# Altium schematic sheet ESP32_C3FH4.SchDoc(ESP32_C3FH4)
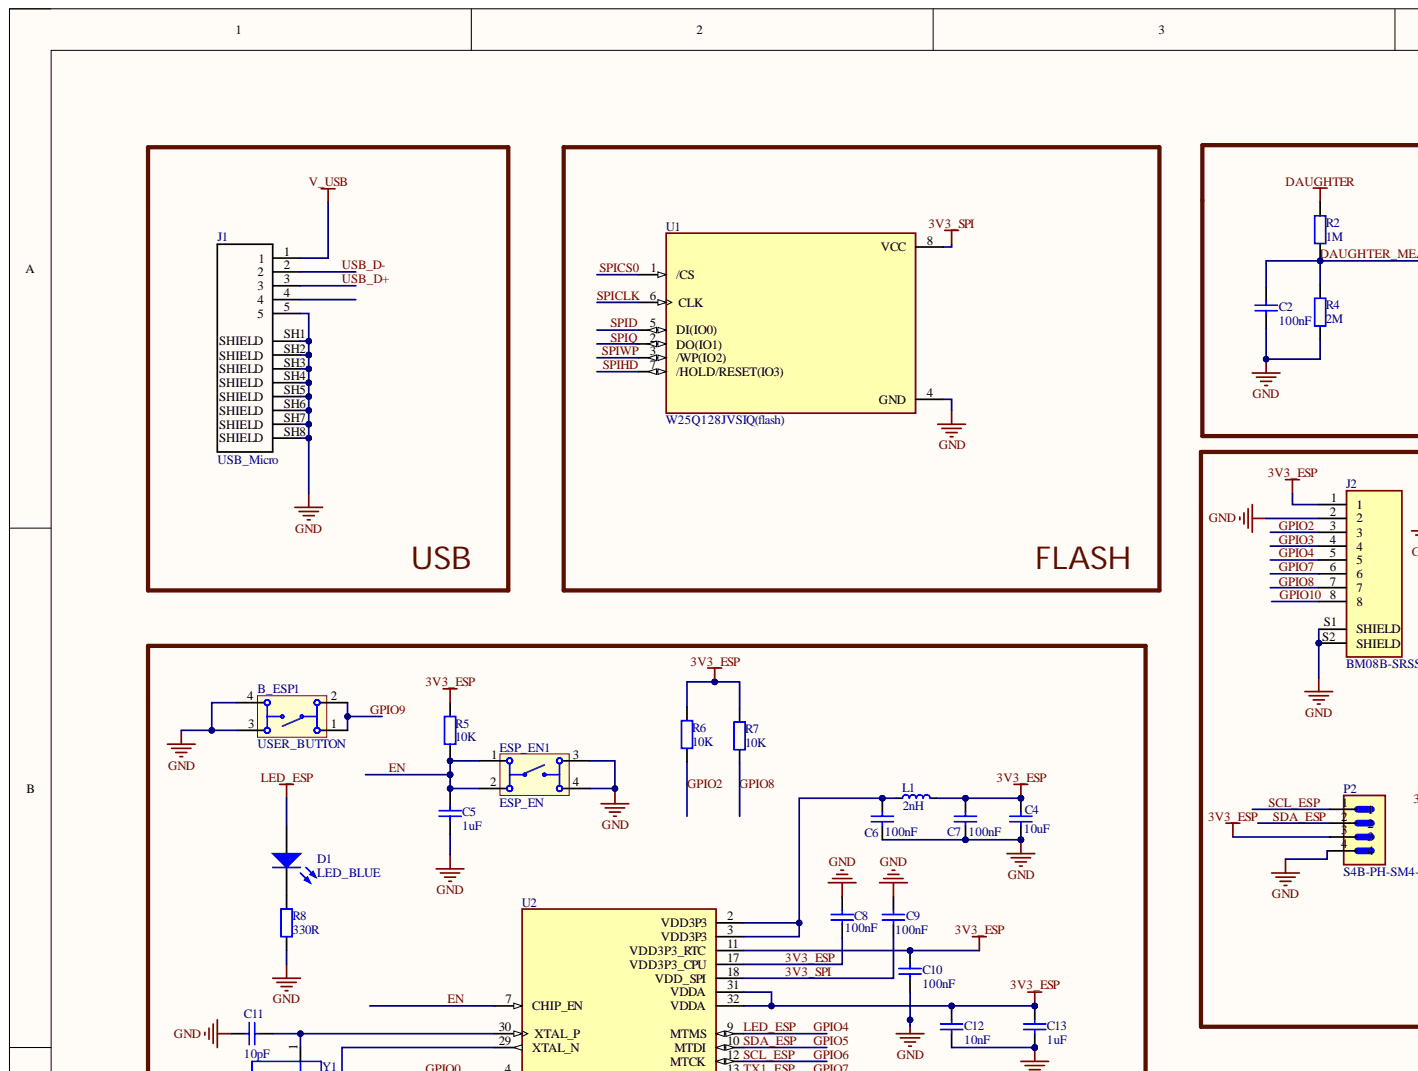
[diagram p0: ESP32_C3FH4.SchDoc, top left]
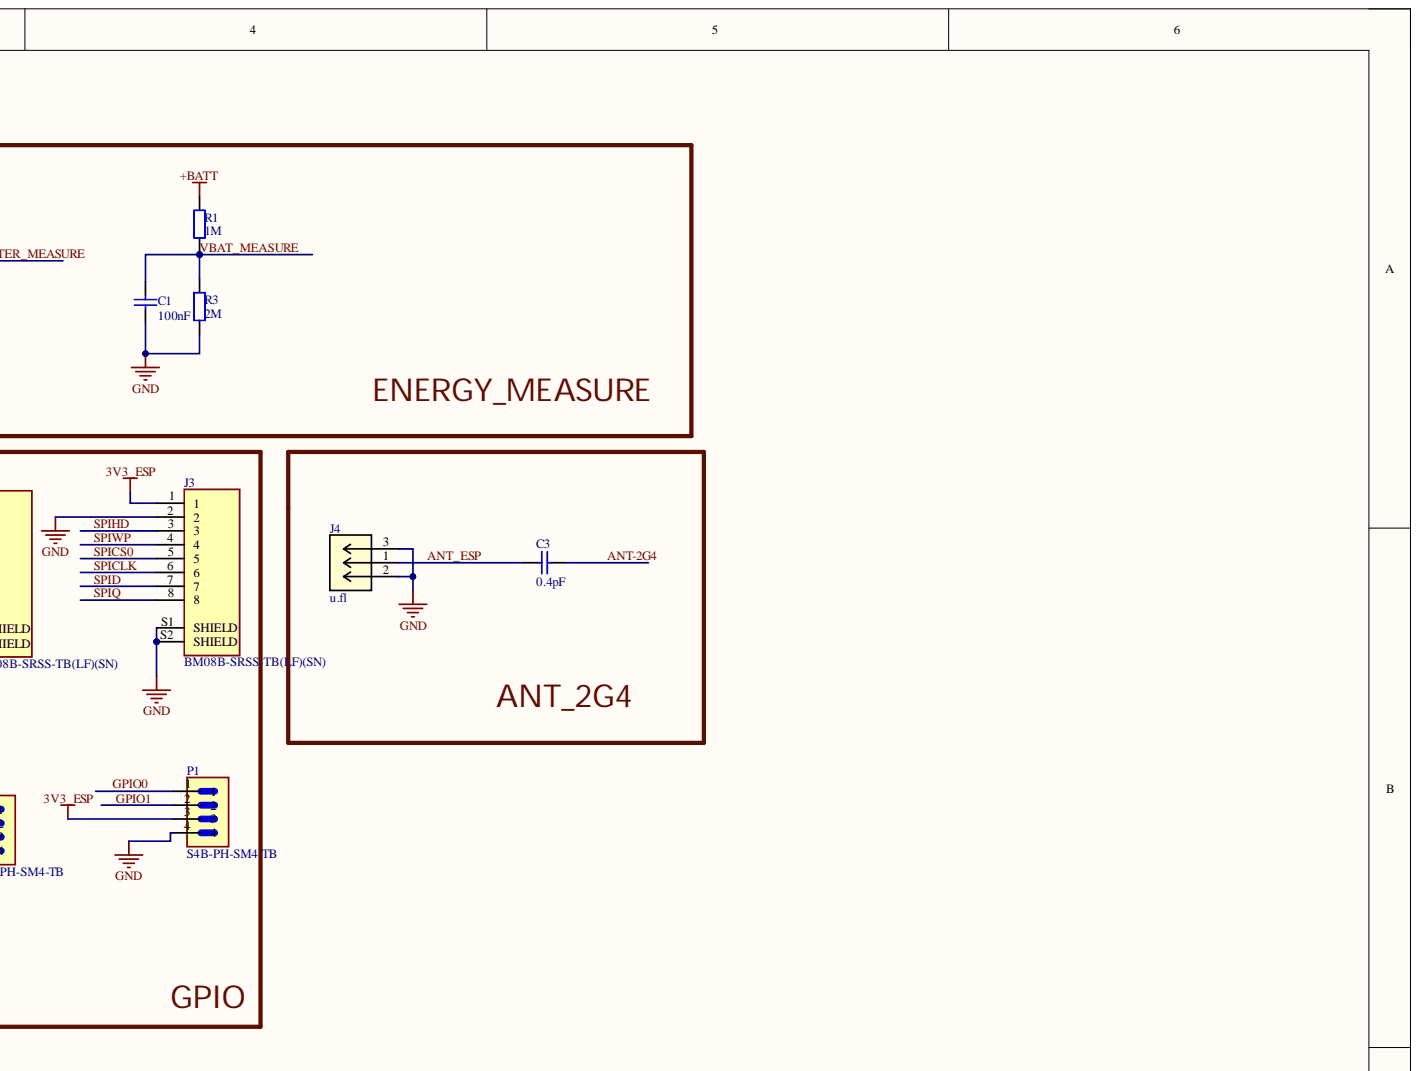
[diagram p1: ESP32_C3FH4.SchDoc, top right]
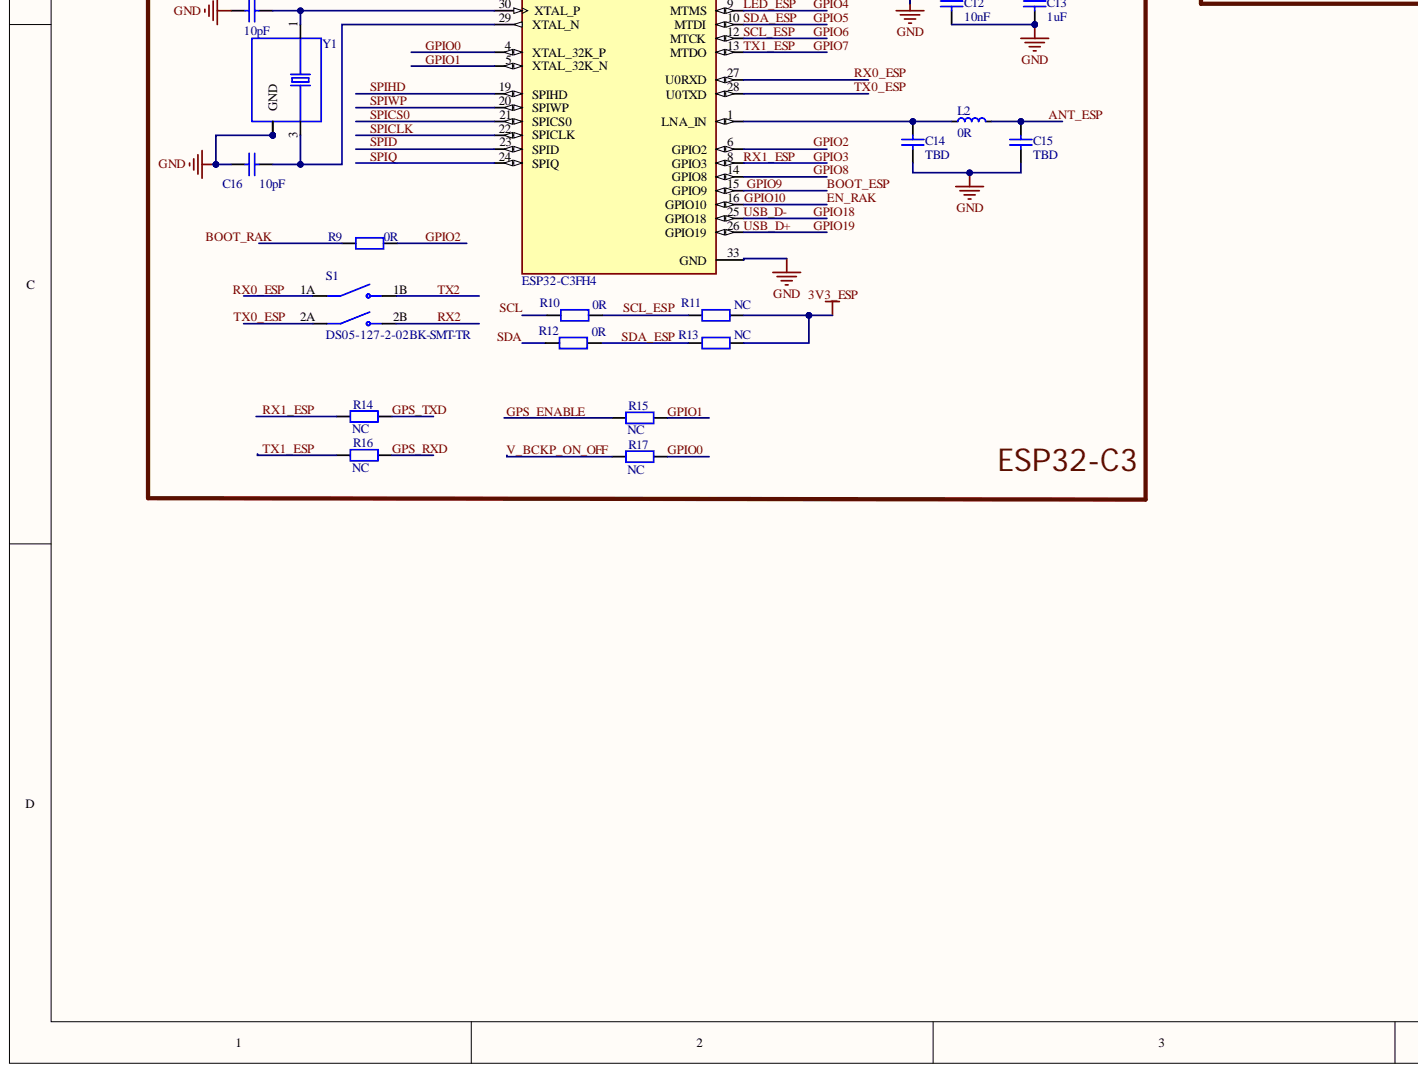
[diagram p2: ESP32_C3FH4.SchDoc, bottom left]
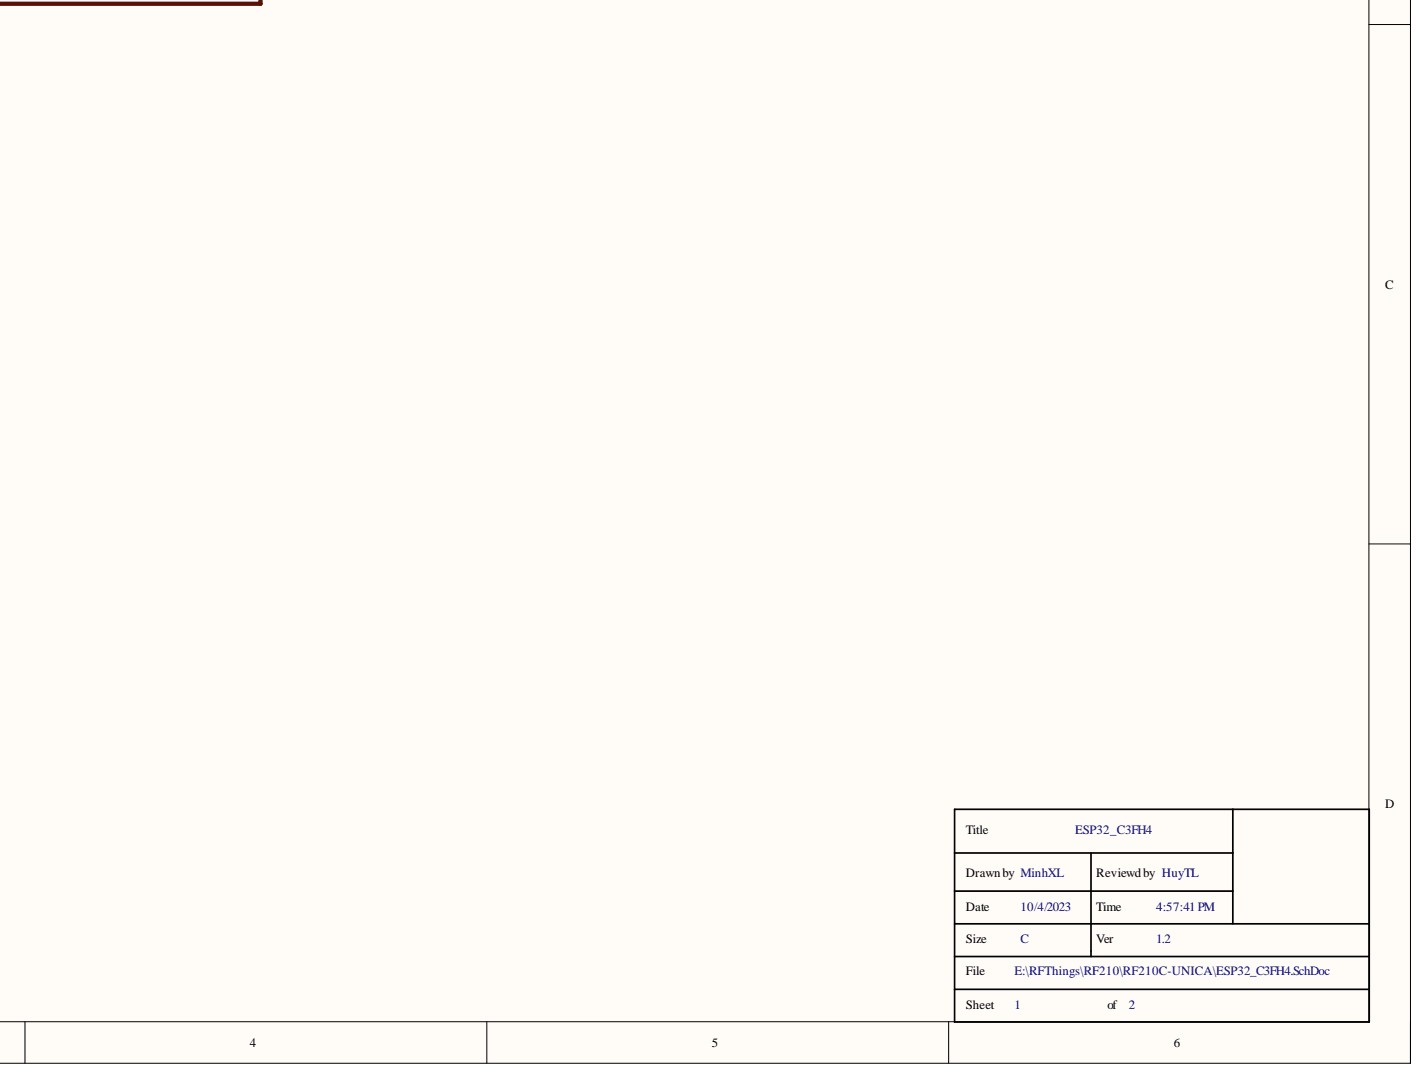
[diagram p3: ESP32_C3FH4.SchDoc, bottom right]

## Parts
*1  Title
B_ESP1  USER_BUTTON  [K2-1114UA-A4SW-06]
C1  value 100nF  [rlc_402_smd]  (hidden comment: Cap)
C2  value 100nF  [rlc_402_smd]  (hidden comment: Cap)
C3  value 0.4pF  [rlc_402_smd]  (hidden comment: Cap)
C4  value 10uF  [rlc_402_smd]  (hidden comment: Cap)
C5  value 1uF  [rlc_402_smd]  (hidden comment: Cap)
C6  value 100nF  [rlc_402_smd]  (hidden comment: Cap)
C7  value 100nF  [rlc_402_smd]  (hidden comment: Cap)
C8  value 100nF  [rlc_402_smd]  (hidden comment: Cap)
C9  value 100nF  [rlc_402_smd]  (hidden comment: Cap)
C10  value 100nF  [rlc_402_smd]  (hidden comment: Cap)
C11  value 10pF  [rlc_402_smd]  (hidden comment: Cap)
C12  value 10nF  [rlc_402_smd]  (hidden comment: Cap)
C13  value 1uF  [rlc_402_smd]  (hidden comment: Cap)
C14  value TBD  [rlc_402_smd]  (hidden comment: Cap)
C15  value TBD  [rlc_402_smd]  (hidden comment: Cap)
C16  value 10pF  [rlc_402_smd]  (hidden comment: Cap)
D1  LED_BLUE  [CD1005-0402]
ESP_EN1  ESP_EN  [K2-1114UA-A4SW-06]
J1  USB_Micro  [*MOLEX_1050170001_1050170001(Primary)]
J2  BM08B-SRSS-TB(LF)(SN)  [JST_BM08B-SRSS-TB(LF)(SN)]
J3  BM08B-SRSS-TB(LF)(SN)  [JST_BM08B-SRSS-TB(LF)(SN)]
J4  u.fl  [UFL Connector]
L1  value 2nH  [rlc_402_smd]  (hidden comment: Inductor small symbol)
L2  value 0R  [rlc_402_smd]  (hidden comment: Inductor small symbol)
P1  S4B-PH-SM4-TB  [JST_S4B-PH-SM4-TB]
P2  S4B-PH-SM4-TB  [JST_S4B-PH-SM4-TB]
R1  value 1M  [rlc_402_smd]  (hidden comment: Resistor)
R2  value 1M  [rlc_402_smd]  (hidden comment: Resistor)
R3  value 2M  [rlc_402_smd]  (hidden comment: Resistor)
R4  value 2M  [rlc_402_smd]  (hidden comment: Resistor)
R5  value 10K  [rlc_402_smd]  (hidden comment: Resistor)
R6  value 10K  [rlc_402_smd]  (hidden comment: Resistor)
R7  value 10K  [rlc_402_smd]  (hidden comment: Resistor)
R8  value 330R  [rlc_402_smd]  (hidden comment: Resistor)
R9  value 0R  [rlc_402_smd]  (hidden comment: Resistor)
R10  value 0R  [rlc_402_smd]  (hidden comment: Resistor)
R11  value NC  [rlc_402_smd]  (hidden comment: Resistor)
R12  value 0R  [rlc_402_smd]  (hidden comment: Resistor)
R13  value NC  [rlc_402_smd]  (hidden comment: Resistor)
R14  value NC  [rlc_402_smd]  (hidden comment: Resistor)
R15  value NC  [rlc_402_smd]  (hidden comment: Resistor)
R16  value NC  [rlc_402_smd]  (hidden comment: Resistor)
R17  value NC  [rlc_402_smd]  (hidden comment: Resistor)
S1  DS05-127-2-02BK-SMT-TR  [SW_DS05-127-2-02BK-SMT-TR]
U1  W25Q128JVSIQ(flash)  [SOIC127P790X216-8N]
U2  ESP32-C3FH4  [QFN50P500X500X90-33N]
Y1  NX2520SA-24.000000MHZ-B8  [XTAL_NX2520SA-24.000000MHZ-B8]  (value not drawn)

## Nets
+BATT: R1.2
DAUGHTER: R2.2
GND: B_ESP1.3 B_ESP1.4 C1.1 C2.1 C4.1 C5.1 C6.1 C7.1 C8.2 C9.2 C10.1 C11.2 C12.1 C13.1 C14.1 C15.1 C16.2 ESP_EN1.3 ESP_EN1.4 J1.5 J1.SH1(SHIELD) J1.SH2(SHIELD) J1.SH3(SHIELD) J1.SH4(SHIELD) J1.SH5(SHIELD) J1.SH6(SHIELD) J1.SH7(SHIELD) J1.SH8(SHIELD) J2.2 J2.S1(SHIELD) J2.S2(SHIELD) J3.2 J3.S1(SHIELD) J3.S2(SHIELD) J4.2 J4.3 P1.4 P2.4 R3.1 R4.1 R8.2 U1.4(GND) U2.33(GND) Y1.2(GND) Y1.4
V_USB: J1.1
3V3_ESP: C4.2 C7.2 C8.1 C10.2 C12.2 C13.2 J2.1 J3.1 L1.2 P1.3 P2.3 R5.1 R6.2 R7.1 R11.1 R13.1 U2.11(VDD3P3_RTC) U2.17(VDD3P3_CPU) U2.31(VDDA) U2.32(VDDA)
3V3_SPI: C9.1 U1.8(VCC) U2.18(VDD_SPI)
ANT-2G4: C3.1
ANT_ESP: C3.2 C15.2 J4.1 L2.2
BOOT_ESP: B_ESP1.1 B_ESP1.2 U2.15(GPIO9)
BOOT_RAK: R9.2
DAUGHTER_MEASURE: C2.2 R2.1 R4.2
EN: C5.2 ESP_EN1.1 ESP_EN1.2 R5.2 U2.7(CHIP_EN)
EN_RAK: J2.8 U2.16(GPIO10)
GPIO0: P1.1 R17.1 U2.4(XTAL_32K_P)
GPIO1: P1.2 R15.1 U2.5(XTAL_32K_N)
GPIO2: J2.3 R6.1 R9.1 U2.6(GPIO2)
GPIO3: J2.4 R14.2 U2.8(GPIO3)
GPIO4: D1.1 J2.5 U2.9(MTMS)
GPIO5: P2.2 R12.1 R13.2 U2.10(MTDI)
GPIO6: P2.1 R10.1 R11.2 U2.12(MTCK)
GPIO7: J2.6 R16.2 U2.13(MTDO)
GPIO8: J2.7 R7.2 U2.14(GPIO8)
GPIO18: J1.2 U2.25(GPIO18)
GPIO19: J1.3 U2.26(GPIO19)
GPS_ENABLE: R15.2
GPS_RXD: R16.1
GPS_TXD: R14.1
RX0_ESP: S1.1A U2.27(U0RXD)
RX2: S1.2B
SCL: R10.2
SDA: R12.2
SPICLK: J3.6 U1.6(CLK) U2.22(SPICLK)
SPICS0: J3.5 U1.1(/CS) U2.21(SPICS0)
SPID: J3.7 U1.5(DI(IO0)) U2.23(SPID)
SPIHD: J3.3 U1.7(/HOLD/RESET(IO3)) U2.19(SPIHD)
SPIQ: J3.8 U1.2(DO(IO1)) U2.24(SPIQ)
SPIWP: J3.4 U1.3(/WP(IO2)) U2.20(SPIWP)
TX0_ESP: S1.2A U2.28(U0TXD)
TX2: S1.1B
V_BCKP_ON_OFF: R17.2
VBAT_MEASURE: C1.2 R1.1 R3.2
NetC6_2: C6.2 L1.1 U2.2(VDD3P3) U2.3(VDD3P3)
NetC11_1: C11.1 U2.30(XTAL_P) Y1.1
NetC14_2: C14.2 L2.1 U2.1(LNA_IN)
NetC16_1: C16.1 U2.29(XTAL_N) Y1.3
NetD1_2: D1.2 R8.1

Not connected: J1 4

## Library data of the parts
B_ESP1 ESP_EN1 = K2-1114UA-A4SW-06: 3.6mm Rectangle button 50mA Surface Mount 4.8mm SPST 12V SMD,4.8x3.6x1.65mm Tactile Switches ROHS; Manufacturer: Wuerth Elektronik; PartNumber: 436333045822; Component Kind: Standard; ComponentLink1Description: Manufacturer URL; ComponentLink1URL: http://www.we-online.com; ComponentLink2Description: Datasheet; ComponentLink2URL: http://katalog.we-online.de/em/datasheet/436333045822.pdf; Library Name: K2-1114UA-A4SW-06.SchLib; PackageReference: 436333045822
C1-C16 = Cap: Capacitor SMD; Component Kind: Standard; LatestRevisionDate: 05-oct-2007  -  Semtech; LatestRevisionNote: Re-released for DXP Platform.; Library Name: LR1110_e516v02b.SchLib; Published: 8-Jun-2000; Signal Integrity: Cap Semi; Simulation: CAP; Publisher: Altium Limited
D1 = LED: Component Kind: Standard; Description: LED; LatestRevisionDate: 05-oct-2007  -  Semtech; Library Name: LR1110_e516v02b.SchLib
J1 = 1050170001: USB - micro B USB 2.0 Receptacle Connector 5 Position Surface Mount, Right Angle; Through Hole; MF: Molex; Component Kind: Standard; Digi-Key_Part_Number: WM1399CT-ND; Digi-Key_Purchase_URL: https://www.digikey.com/product-detail/en/molex/1050170001/WM1399CT-ND/2350885?utm_source=snapeda&utm_medium=aggregator&utm_campaign=symbol; Library Name: 1050170001.schlib; MP: 1050170001; Package: None; SnapEDA_Link: https://www.snapeda.com/parts/1050170001/Molex/view-part/2755530/?ref=snap
J2 J3 = BM08B-SRSS-TB(LF)(SN): Connector Header Surface Mount 8 position 0.039 (1.00mm); MF: JST Sales; Availability: In Stock; Check_prices: https://www.snapeda.com/parts/BM08B-SRSS-TB(LF)(SN)/JST+Sales+America+Inc./view-part/?ref=eda; Component Kind: Standard; Library Name: BM08B-SRSS-TB.IntLib; MP: BM08B-SRSS-TB(LF)(SN); Package: None; Price: None; Purchase-URL: https://pricing.snapeda.com/search/part/BM08B-SRSS-TB(LF)(SN)/?ref=eda; SnapEDA_Link: https://www.snapeda.com/parts/BM08B-SRSS-TB(LF)(SN)/JST+Sales+America+Inc./view-part/?ref=snap
J4 = U.FL-R-SMT: No Description Available; Manufacturer_Part_Number: U.FL-R-SMT-1(10); Built_By: EMA_Matt; Vendor: Hirose; Component Kind: Standard; Library Name: MyLibrary-001.SchLib; RefDes: RefDes; Type: DEV; Value: Value; Copyright: Copyright (C) 2018 Accelerated Designs. All rights reserved
L1 L2 = Inductor_small: Inductor; Code_IEC: 1005; Code_JEITA: 0402; Component Kind: Standard; LatestRevisionDate: 05-oct-2007  -  Semtech; LatestRevisionNote: Re-released for DXP Platform.; Library Name: LR1110_e516v02b.SchLib; Published: 8-Jun-2000; Publisher: Altium Limited
P1 P2 = S4B-PH-SM4-TB: Connector Header Surface Mount, Right Angle 4 position 0.079 _2.00mm_; MF: JST Sales; Availability: Unavailable; Component Kind: Standard; Library Name: S4B-PH-SM4-TB.IntLib; MP: S4B-PH-SM4-TB; Package: None; Price: None; SnapEDA_Link: https://www.snapeda.com/parts/S4B-PH-SM4-TB/JST%20Sales%20America%20Inc./view-part/473686/?ref=snap
R1-R17 = Resistor: Resistor SMD - IEC symbol version; Component Kind: Standard; LatestRevisionDate: 27-Jul-20  -  Semtech
  R1-R4: Library Name: LR1110_e516v02b.SchLib
  R5-R17: Library Name: Gemini_LR1120.IntLib
U1 = W25Q128JVSIQ: FLASH - NOR Memory IC 128Mb (16M x 8) SPI - Quad I/O, QPI, DTR 133 MHz 8-SOIC; MF: Winbond Electronics; Availability: In Stock; Check_prices: https://www.snapeda.com/parts/W25Q128JVSIQ/Winbond+Electronics/view-part/?ref=eda; Component Kind: Standard; Library Name: W25Q128JVSIQ.IntLib; MP: W25Q128JVSIQ; Package: SOIC-8 Winbond; Price: None; Purchase-URL: https://pricing.snapeda.com/search/part/W25Q128JVSIQ/?ref=eda; SnapEDA_Link: https://www.snapeda.com/parts/W25Q128JVSIQ/Winbond+Electronics/view-part/?ref=snap
U2 = ESP32-C3FH4: Bluetooth, WiFi 802.11b/g/n, Bluetooth v5.0 Transceiver Module 2.402GHz ~ 2.48GHz Antenna Not Included Surface Mount; MF: Espressif Systems; Availability: In Stock; Check_prices: https://www.snapeda.com/parts/ESP32-C3FH4/Espressif%20Systems/view-part/5881917/?ref=eda; Component Kind: Standard; Library Name: ESP32-C3FH4.IntLib; MP: ESP32-C3FH4; Package: VFQFN-32 Espressif Systems; Price: None; Purchase-URL: https://pricing.snapeda.com/search/part/ESP32-C3FH4/?ref=eda; SnapEDA_Link: https://www.snapeda.com/parts/ESP32-C3FH4/Espressif%20Systems/view-part/5881917/?ref=snap
Y1 = NX2520SA-24.000000MHZ-B8: 24MHz Â±10ppm Crystal 10pF 100 Ohms 4-SMD, No Lead; MF: NDK America, Inc.; Availability: Unavailable; Component Kind: Standard; Library Name: NX2520SA-24.000000MHZ-B8.IntLib; MP: NX2520SA-24.000000MHZ-B8; Package: SMD-4 NDK America, Inc.; Price: None; SnapEDA_Link: https://www.snapeda.com/parts/NX2520SA-24.000000MHZ-B8/NDK%20America%2C%20Inc./view-part/3076058/?ref=snap
*1 = Title: Component Kind: Standard; Library Name: title.SchLib
S1 = DS05-127-2-02BK-SMT-TR: Availability: Unavailable; Component Kind: Standard; Library Name: DS05-127-2-02BK-SMT-TR.IntLib; MP: DS05-127-2-02BK-SMT-TR; Package: None; Price: None
